# Altium schematic sheet ramp_generator.SchDoc(ramp_generator)
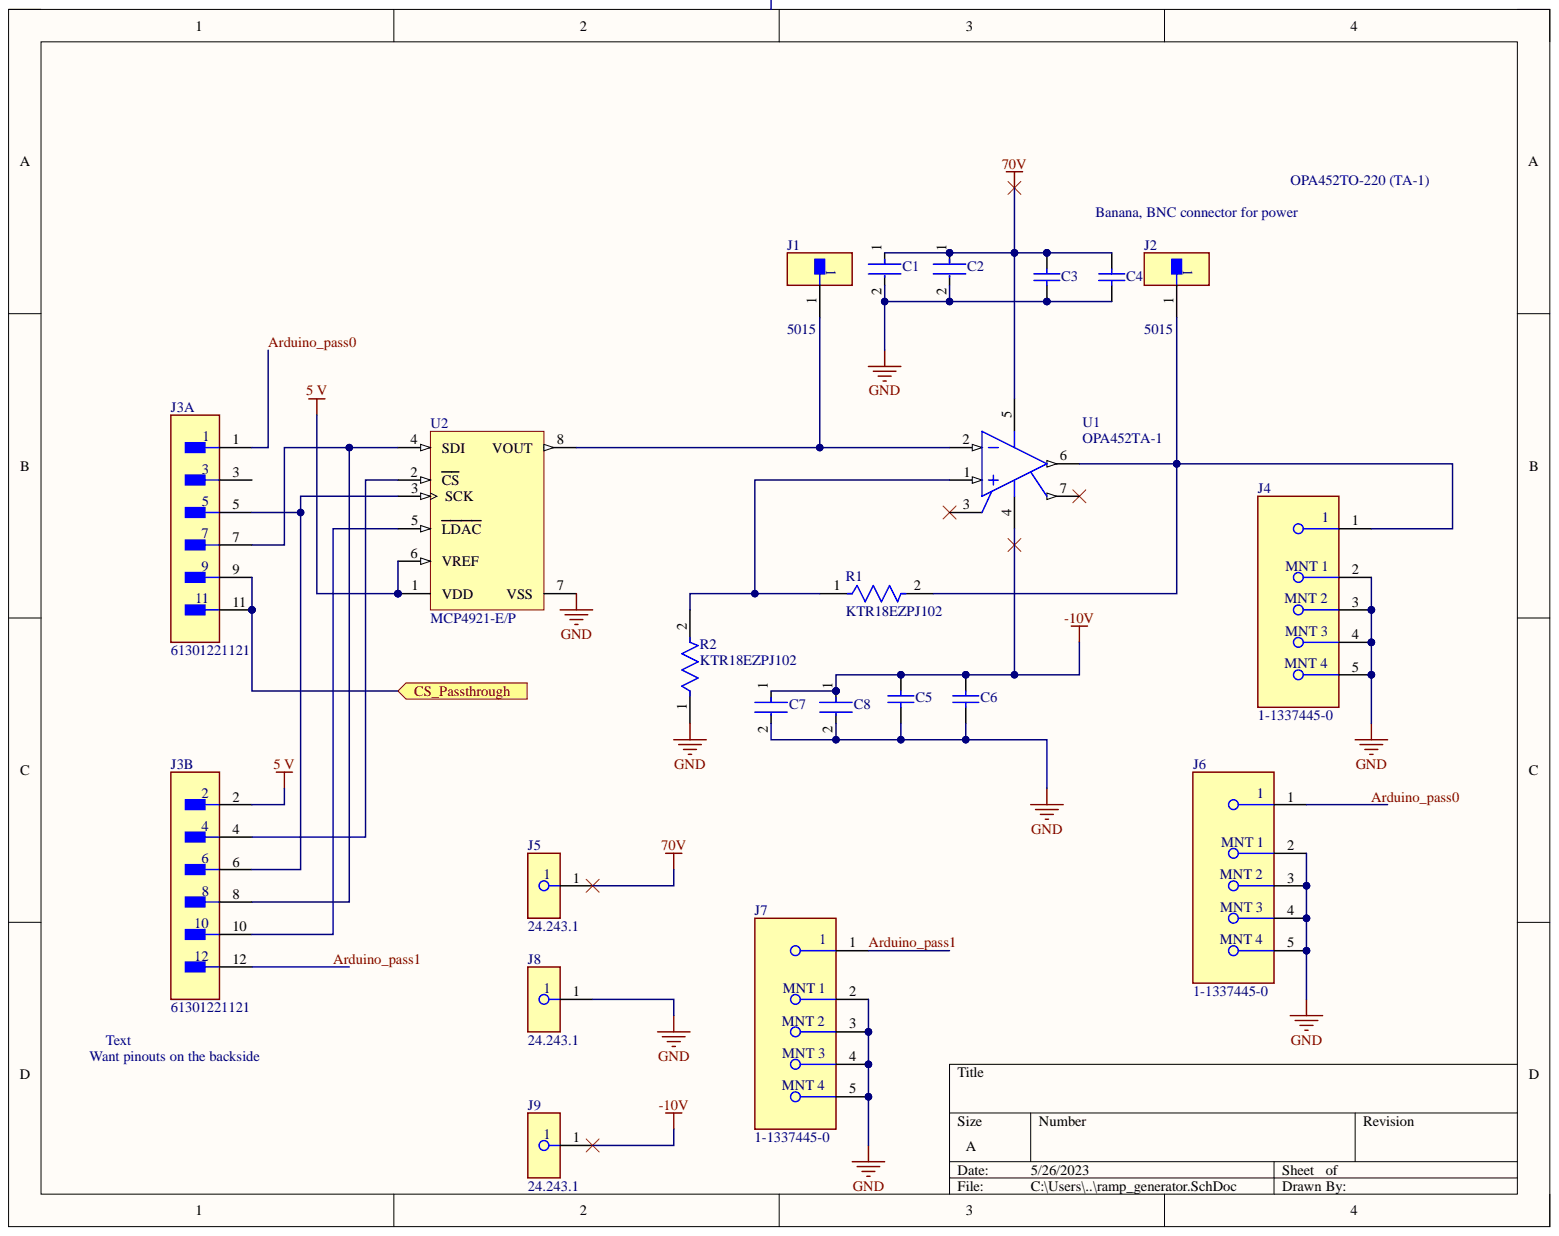
[diagram p0: ramp_generator.SchDoc]

## Parts
C1  C3216JB1A106M160AA  [FP-C3216-160-0_2-IPC_C]  (value not drawn)
C2  C3216JB1A106M160AA  [FP-C3216-160-0_2-IPC_C]  (value not drawn)
C3  CAP 100pF 100V 1206(3216)  [CAPC1206(3216)100_N]  (value not drawn)
C4  CAP 100pF 100V 1206(3216)  [CAPC1206(3216)100_N]  (value not drawn)
C5  CAP 100pF 100V 1206(3216)  [CAPC1206(3216)100_N]  (value not drawn)
C6  CAP 100pF 100V 1206(3216)  [CAPC1206(3216)100_N]  (value not drawn)
C7  C3216JB1A106M160AA  [FP-C3216-160-0_2-IPC_C]  (value not drawn)
C8  C3216JB1A106M160AA  [FP-C3216-160-0_2-IPC_C]  (value not drawn)
J1  5015  [FP-5015-MFG]
J2  5015  [FP-5015-MFG]
J3  61301221121  [FP-61301221121-MFG]  (parts A,B)
J4  1-1337445-0  [FP-1-1337445-0-MFG]
J5  24.243.1  [FP-24_243_1-MFG]
J6  1-1337445-0  [FP-1-1337445-0-MFG]
J7  1-1337445-0  [FP-1-1337445-0-MFG]
J8  24.243.1  [FP-24_243_1-MFG]
J9  24.243.1  [FP-24_243_1-MFG]
R1  KTR18EZPJ102  [FP-KTR18-IPC_A]
R2  KTR18EZPJ102  [FP-KTR18-IPC_A]
U1  OPA452TA-1  [KVT0007A]
U2  MCP4921-E/P  [PDIP300-P8]

## Ports
CS_Passthrough

## Nets
5 V: J3.2 U2.1(VDD) U2.6(VREF)
70V: C1.1 C2.1 C3.2 C4.2 J5.1 U1.5
-10V: C5.2 C6.1 C7.1 C8.1 J9.1 U1.4
GND: C1.2 C2.2 C3.1 C4.1 C5.1 C6.2 C7.2 C8.2 J4.2 J4.3 J4.4 J4.5 J6.2 J6.3 J6.4 J6.5 J7.2 J7.3 J7.4 J7.5 J8.1 R2.1 U2.7(VSS)
Arduino_pass0: J3.1 J6.1
Arduino_pass1: J3.12 J7.1
CS_Passthrough: J3.9 J3.11 ; port CS_Passthrough
NetJ1_1: J1.1 U1.2 U2.8(VOUT)
NetJ2_1: J2.1 J4.1 R1.2 U1.6
NetJ3_4: J3.4 U2.2(CS)
NetJ3_5: J3.5 J3.6 U2.3(SCK)
NetJ3_7: J3.7 J3.8 U2.4(SDI)
NetJ3_10: J3.10 U2.5(LDAC)
NetR1_1: R1.1 R2.2 U1.1

Not connected: J3 3; U1 3 7

## Library data of the parts
C1 C2 C7 C8 = CMP-08246-004766-1: Multilayer Ceramic Capacitors 10uF ±20% 10V JB SMD 1206; Capacitance: 10uF; Case Code (Imperial): 1206; Case Code (Metric): 3216; Case/Package: 1206; Component Kind: Standard; Dielectric Material: Ceramic; Lead Free: Lead Free; Length: 0.126inch; Library Name: Altium Content Vault; Max Operating Temperature: 85°C; Min Operating Temperature: -25°C; Mount: Surface Mount; Package Quantity: 2000; Packaging: Cut Tape; RoHS Compliant: Yes; Series: C; Thickness: 0.069inch; Tolerance: 20%; Voltage Rating: 10V; Voltage Rating (DC): 10V; Width: 0.063inch
C3-C6 = CMP-1037-01579-1: CAP 100pF 100V ±1% 1206 (3216 Metric) Thickness 1mm SMD; Case-EIA: 1206; Case-Metric: 3216; Tolerance: Â±1%; Component Kind: Standard; Library Name: Altium Content Vault; Max Thickness: 1 mm; Rated Voltage: 100 V; Technology: SMT; Value: 100pF
J1 J2 = CMP-2000-05183-2: PC TEST POINT MINIATURE; Manufacturer: keystone; Conn Orientation: Straight; Number Of Contacts: 1; Automotive: No; Category: Conn; Component Kind: Standard; ComponentLink2Description: Datasheet; ComponentLink2URL: http://www.keyelco.com/product-pdf.cfm?p=1353; Contact Material: Silver; Device Class L1: Connectors; Device Class L2: unset; Device Class L3: unset; Digikey Description: PC TEST POINT MINIATURE; Height: 1.65mm; Lead Free: Yes; Library Name: Altium Content Vault; Manufacturer Part Number: 5015; Octopart: https://octopart.com/5015-keystone-3822; Package: 5015; RoHS: TRUE
J3 = CMP-1502-01088-2: CONN HEADER VERT 12POS 2.54MM; Gender: Male; Number of Contacts: 12; Pitch: 0.1inch; Voltage Rating: 250V; Color: Black; Component Kind: Standard; Connector Type: Header; Contact Finish - Mating: GOLD; Contact Material: Copper Alloy; Contact Mating Length: 0.236inch; Contact Plating: Gold; Contact Shape: Square; Contact Type: Male Pin; Fastening Type: Pull; Flammability Rating: UL94 V-0; Insulation Color: Black; Insulation Material: Nylon; Library Name: Altium Content Vault; Max Operating Temperature: 105°C; Min Operating Temperature: -40°C; Mount: Through Hole; Number of Positions: 12; Number of Rows: 2; Packaging: Bulk; RoHS Compliant: Yes; Row Spacing: 0.1inch; Series: WR-PHD; Termination: Solder
J4 J6 J7 = CMP-03357-000006-1: CONN BNC JACK STR 50 OHM PCB; Body Material: Brass; Body Plating: Silver; Dielectric Material: PTFE; Frequency: 4GHz; Gender: Female; Impedance: 50R; Insulation Resistance: 5GR; Number of Positions: 1; Operating Frequency: 0 to 4Hz; PCB Mount Retention: With; PCB Mounting Orientation: Vertical; PCB Thickness: 0.126inch; Sealable: No (Without); Component Kind: Standard; Connector Type: BNC; Contact Material: Brass; Contact Plating: Silver; Depth: 12mm; ELV: Compliant; Fastening Type: Bayonet; Insulation Material: Polytetrafluoroethylene; Length: 12mm; Library Name: Altium Content Vault; Material: Brass; Max Frequency: 4GHz; Max Operating Temperature: 165°C; Min Operating Temperature: -65°C; Mount: Through Hole; Orientation: Straight; Package Quantity: 50; Packaging: Bag; Radiation Hardening: No; RoHS Compliant: Yes; Series: BNC; Termination: Solder
J5 J8 J9 = CMP-12400-000001-2: Banana Test Connector, 4mm, Socket, PCB Mount, 24 A, 60 VDC, Silver Plated Contacts, Green; Current Rating: 20A; Gender: Male; Voltage Rating: 30V; Component Kind: Standard; Contact Material: Brass; Contact Plating: Silver; Length: 24mm; Library Name: Altium Content Vault; REACH SVHC: No SVHC; RoHS Compliant: Yes; Termination: Solder; Voltage Rating (DC): 30V
R1 R2 = CMP-08839-031408-1: Res Thick Film 1206 1kΩ 5% 0.1W ±200ppm/°C Automotive Paper T/R; Max Power Dissipation: 250mW; Resistance: 1kR; Case Code (Imperial): 1206; Case Code (Metric): 3216; Case/Package: 1206; Component Kind: Standard; Features: Automotive AEC-Q200; Height: 0.026inch; Lead Free: Lead Free; Length: 0.126inch; Library Name: Altium Content Vault; Max Operating Temperature: 155°C; Min Operating Temperature: -55°C; Mount: Surface Mount; Number of Terminations: 2; Package Quantity: 1; Packaging: Tape and Reel; Power Rating: 250mW; Resistor Type: Thick Film; RoHS Compliant: Yes; Series: KTR; Size Code: 1206; Temperature Coefficient: 200ppm/°C; Tolerance: 5%; Width: 0.063inch
U1 = CMP-0272-03697-4: Operational Amplifier, 20 to 80 V, -40 to 125 degC, 7-pin FM (KVT7), Green (RoHS & no Sb/Br); Manufacturer: Texas Instruments; CMRR(Min)(dB): 86; GBW(Typ)(MHz): 1.8; IIB(Max)(pA): 100; Iq per channel(Max)(mA): 6.5; Number of Channels: 1; Offset Drift(Typ)(uV/C): 5; Packing: TUBE; Rating: Catalog; Slew Rate(Typ)(V/us): 10; Sub Family: High Output Current Amplifier (>=50mA), High Supply Voltage Amplifier (>=30V), Precision Amplifier; Total Supply Voltage(Max)(+5V is 5, +/-5V is 10): 80; Total Supply Voltage(Min)(+5V is 5, +/-5V is 10): 20; Vio (25C)(Max)(mV): 3; Component Kind: Standard; ComponentLink1Description: Manufacturer URL; ComponentLink1URL: http://www.ti.com/; ComponentLink2Description: Datasheet; ComponentLink2URL: http://www.ti.com/lit/ds/symlink/opa452.pdf; ComponentLink3Description: Package Specification; ComponentLink3URL: http://www.ti.com/litv/pdf/mpzf004; DatasheetVersion: SBOS127C; Eco-Plan: Green (RoHS & no Sb/Br); Library Name: Altium Content Vault; Mounting Technology: Through Hole; PackageDescription: 7-Pin Plastic Flange Mount, Body 10.16 x 4.495 mm, Pitch 1.27 mm; PackageReference: KVT0007A; PackageVersion: 4204912/A, 01/2003; PartNumber: OPA452TA-1; RevisionNote: Footprint corrected
U2 = CMP-0188-00217-2: 12-Bit DAC with SPI Interface, 8-Pin PDIP, Extended Temperature; Code_JEDEC: MS-001; Component Kind: Standard; ComponentLink1Description: Manufacturer URL; ComponentLink1URL: http://www.microchip.com/; ComponentLink2Description: Datasheet; ComponentLink2URL: http://ww1.microchip.com/downloads/en/DeviceDoc/22248a.pdf; ComponentLink3Description: Package Specification; ComponentLink3URL: http://www.microchip.com/stellent/groups/techpub_sg/documents/packagingspec/en012702.pdf; DatasheetVersion: revA, Apr-2010; Library Name: Altium Content Vault; PackageDescription: 8-Lead Plastic Dual In-Line (P) - 300 mil Body [PDIP]; PackageReference: PDIP300-P8; PackageVersion: revBB, Aug-2009; RevisionNote: URLs added. DatasheetVersion updated. Sch updated.
